# Altium schematic sheet 3_Communication.SchDoc
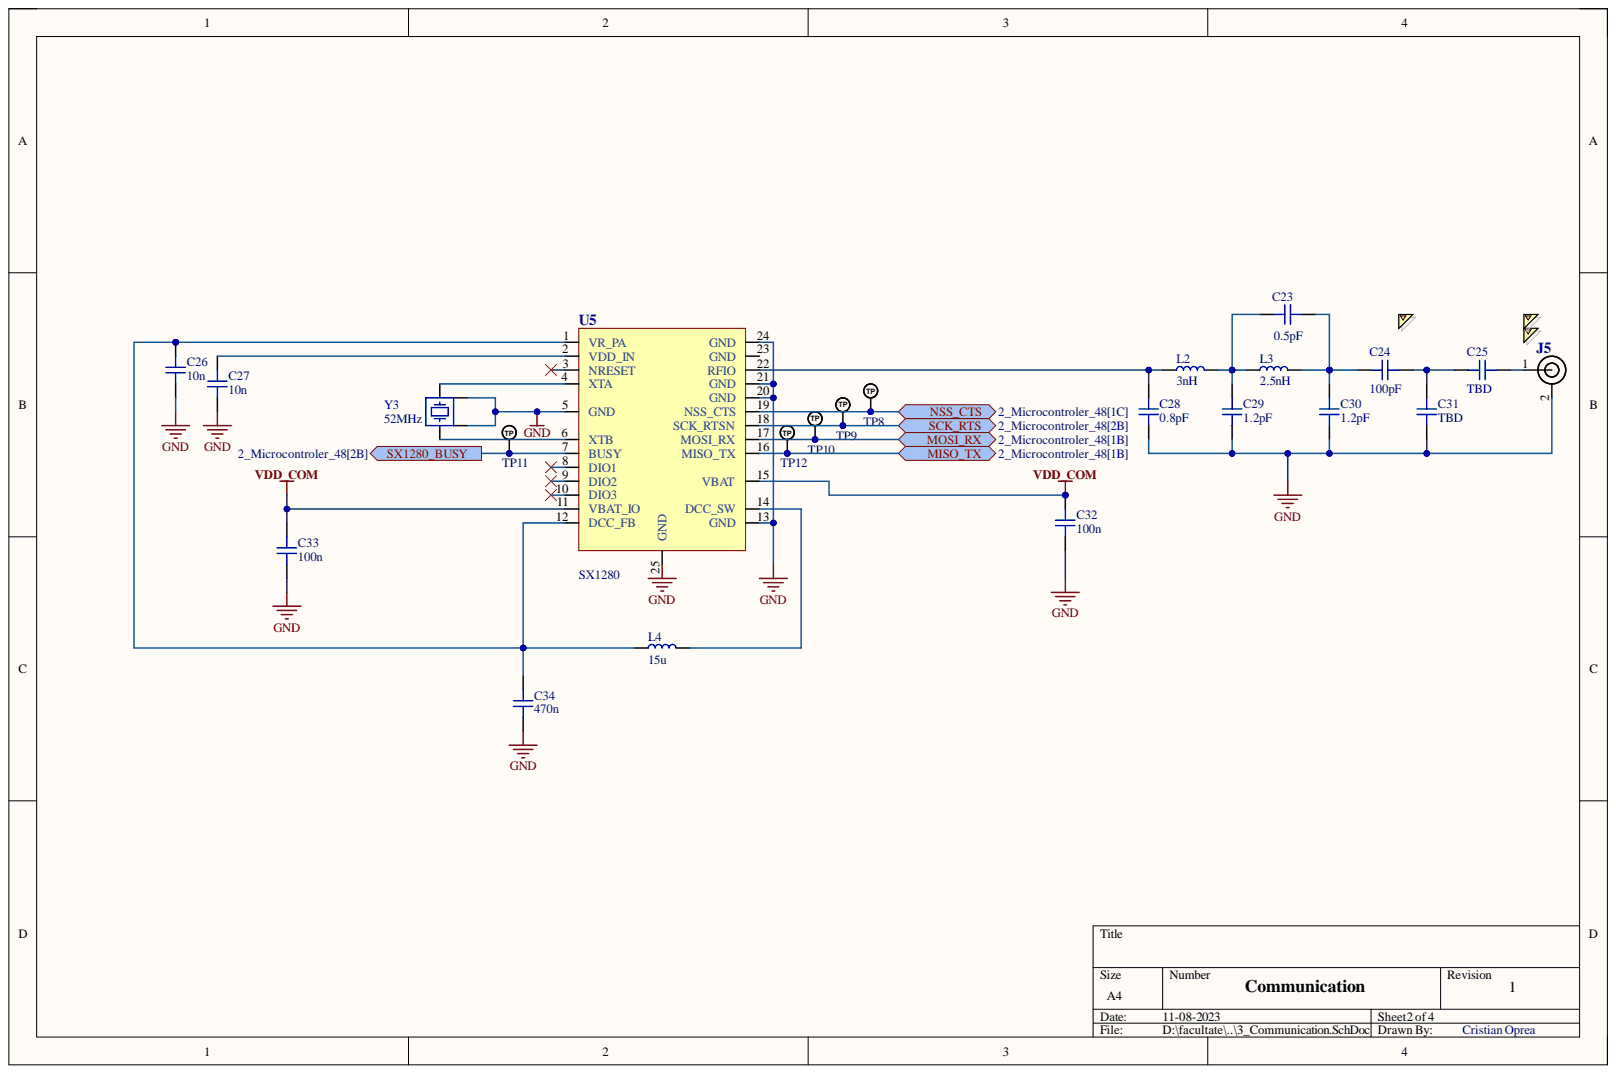
[diagram p0: 3_Communication.SchDoc]

## Parts
C23  0.5pF  [C0603]
C24  100pF  [C0603]
C25  TBD  [C0805]
C26  10n  [C0603]
C27  10n  [C0603]
C28  0.8pF  [C0603]
C29  1.2pF  [C0603]
C30  1.2pF  [C0603]
C31  TBD  [C0805]
C32  100n  [C0603]
C33  100n  [C0603]
C34  470n  [C0603]
J5  SMA_EDGE_CONN  [CON_SMA-EDGE-S]  (value not drawn)
L2  3nH  [R0603]
L3  2.5nH  [R0603]
L4  15u  [IND_3.2_4]
TP8  TP_NOBOM  [TEST_PAD_THD]  (value not drawn)  (no BOM)
TP9  TP_NOBOM  [TEST_PAD_THD]  (value not drawn)  (no BOM)
TP10  TP_NOBOM  [TEST_PAD_THD]  (value not drawn)  (no BOM)
TP11  TP_NOBOM  [TEST_PAD_THD]  (value not drawn)  (no BOM)
TP12  TP_NOBOM  [TEST_PAD_THD]  (value not drawn)  (no BOM)
U5  SX1280  [QFN-24_4x4_0.5]
Y3  52MHz  [CRYSTAL_2016_4P]

## Ports
MISO_TX, MOSI_RX, NSS_CTS, SCK_RTS, SX1280_BUSY

## Nets
GND: C26.1 C27.1 C28.1 C29.1 C30.1 C31.1 C32.1 C33.1 C34.1 J5.2 U5.5(GND) U5.13(GND) U5.20(GND) U5.21(GND) U5.24(GND) U5.25(GND) Y3.2 Y3.4
VDD_COM: C32.2 C33.2 U5.11(VBAT_IO) U5.15(VBAT)
MISO_TX: TP12.1 U5.16(MISO_TX) ; port MISO_TX
MOSI_RX: TP10.1 U5.17(MOSI_RX) ; port MOSI_RX
NSS_CTS: TP8.1 U5.19(NSS_CTS) ; port NSS_CTS
SCK_RTS: TP9.1 U5.18(SCK_RTSN) ; port SCK_RTS
SX1280_BUSY: TP11.1 U5.7(BUSY) ; port SX1280_BUSY
NetC23_1: C23.1 C24.2 C30.2 L3.2
NetC23_2: C23.2 C29.2 L2.2 L3.1
NetC24_1: C24.1 C25.2 C31.2
NetC25_1: C25.1 J5.1
NetC26_2: C26.2 C34.2 L4.1 U5.1(VR_PA) U5.12(DCC_FB)
NetC27_2: C27.2 U5.2(VDD_IN)
NetC28_2: C28.2 L2.1 U5.22(RFIO)
NetL4_2: L4.2 U5.14(DCC_SW)
NetU5_4: U5.4(XTA) Y3.3
NetU5_6: U5.6(XTB) Y3.1

Not connected: U5 3(NRESET) 8(DIO1) 9(DIO2) 10(DIO3) 23(GND)

## Library data of the parts
C23 C24 C26 C27 C29 C30 C32-C34 = CAP_0603: Capacitor SMD 0603; Component Kind: Standard; Library Name: Schlib_H2.SchLib
C25 C31 = CAP_0603: Capacitor SMD 0805; Component Kind: Standard; Library Name: Schlib_H2.SchLib
C28 = CAP_0805: Capacitor SMD 0805; Component Kind: Standard; Library Name: Schlib_H2.SchLib
J5 = CON-SMA-EDGE-S: RF Connector SMA Female 50 Ohms 18 GHz PCB Edge Mount; Manufacturer: RF Solutions; Component Kind: Standard; Library Name: Schlib_H2.SchLib; Manufacturer Part Number: CON-SMA-EDGE-S
L2-L4 = IND_0603: Inductor SMD 0603; Component Kind: Standard; Library Name: Schlib_H2.SchLib
TP8-TP12 = TEST_POINT_RND_1MM: Test Point Round 1mm (NO BOM); Library Name: Schlib_H2.SchLib
U5 = SX1280: Low power LoRa transceiver operating at 2.4GHz with ranging capability; Component Kind: Standard; Library Name: Schlib_H2.SchLib
Y3 = Crystal: Manufacturer: Epson; Manufacturer Part Number: TSX-3225 24.0000MF15X-AC; Component Kind: Standard; Description: Crystal; Library Name: Schlib_H2.SchLib
